# Altium schematic sheet 03_SingleEndstufe.SchDoc(03_SingleEndstufe)
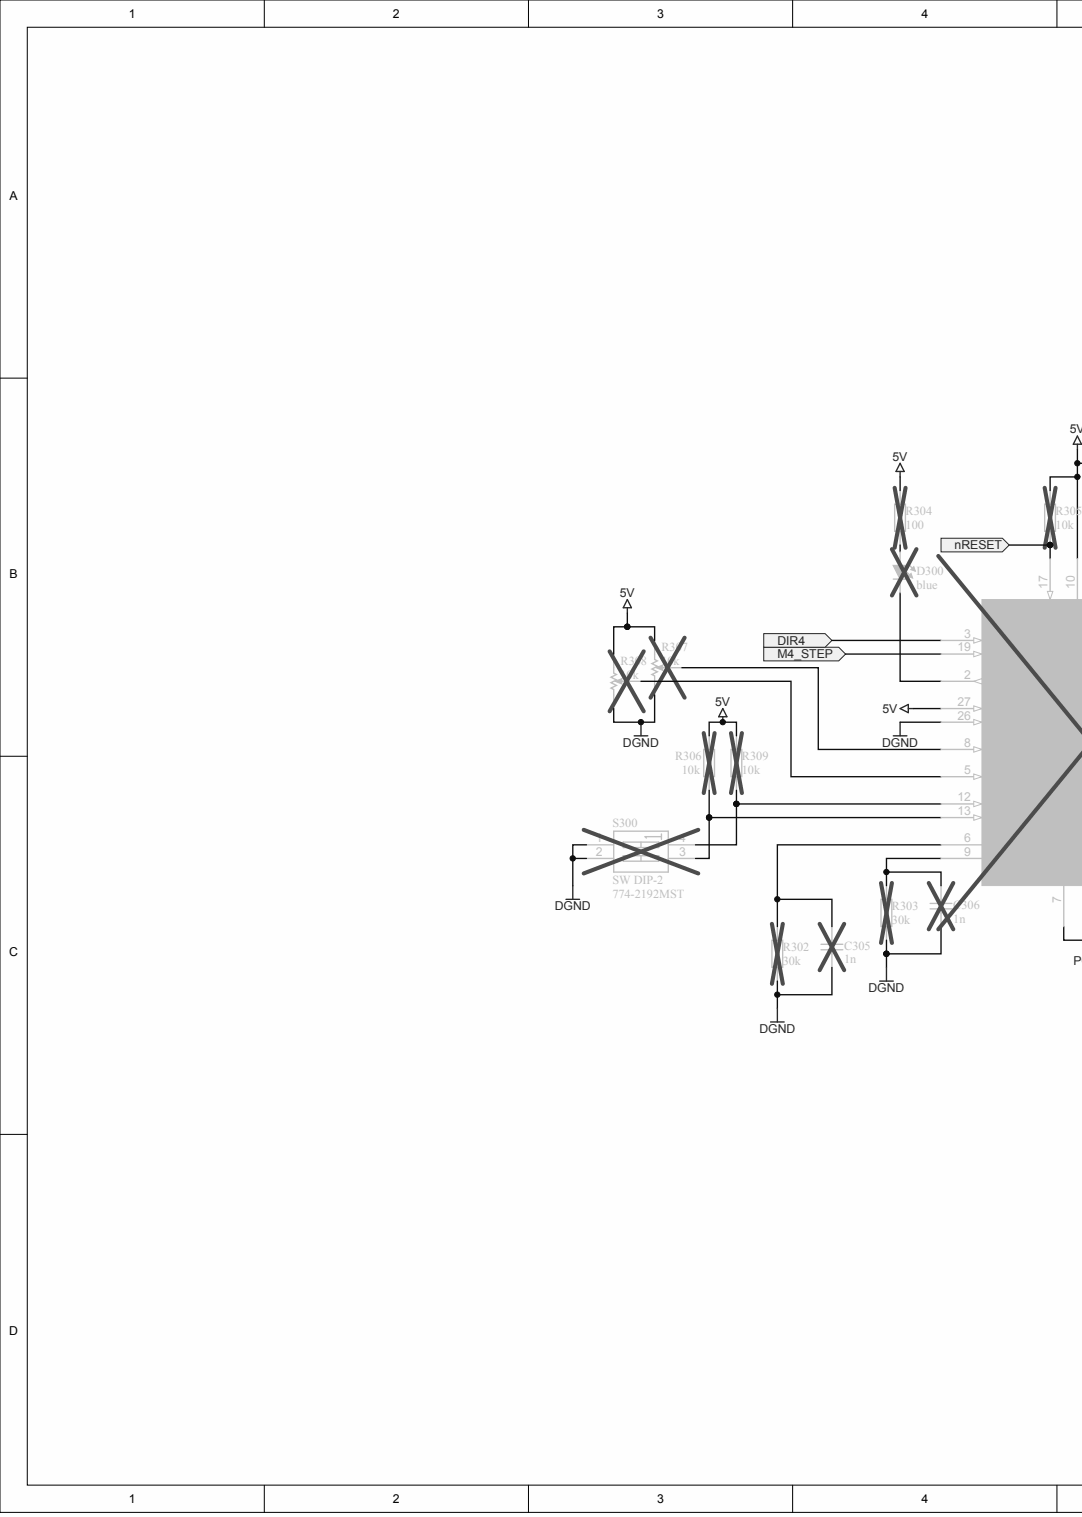
[diagram p0: 03_SingleEndstufe.SchDoc, left]
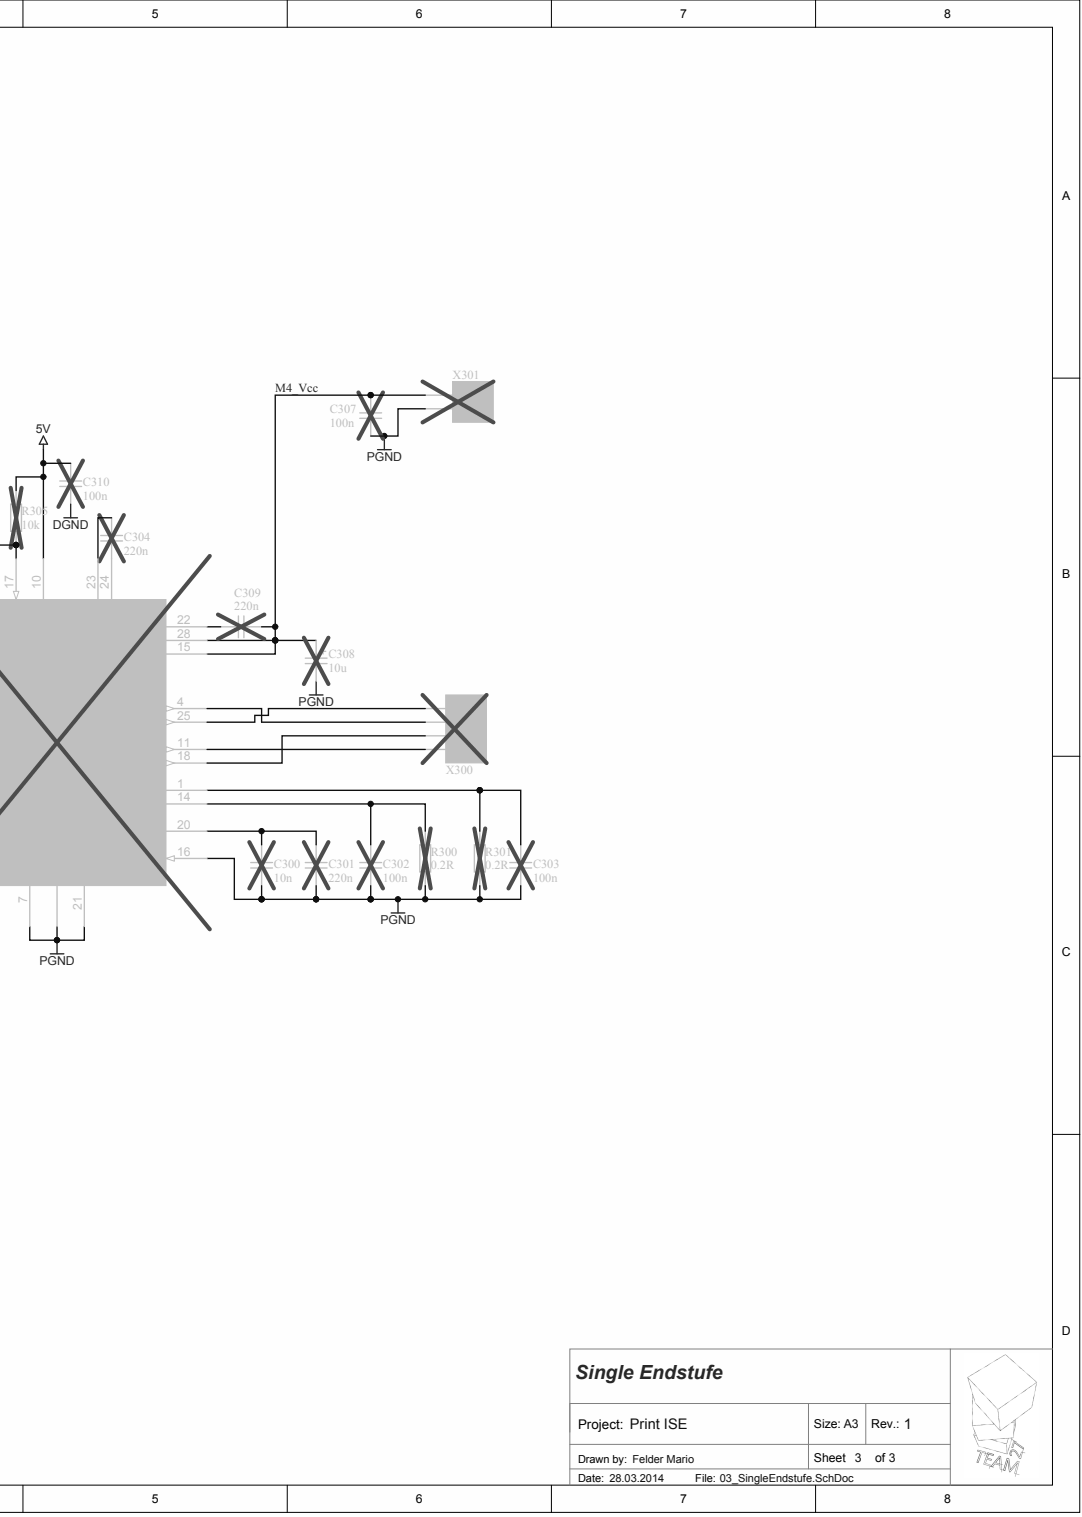
[diagram p1: 03_SingleEndstufe.SchDoc, right]

## Parts
C300  10n
C301  220n
C302  100n
C303  100n
C304  220n
C305  1n
C306  1n
C307  100n
C308  10u
C309  220n
C310  100n
D300  blue
R300  0.2R
R301  0.2R
R302  30k
R303  30k
R304  100
R305  10k
R306  10k
R307  10k
R308  10k
R309  10k
S300  SW DIP-2  Supplier Part Number 1: 774-2192MST
U300  A3977SLP
X300  Motor_Connector  (value not drawn)
X301  Header 2  (value not drawn)

## Ports
DIR4, M4_STEP, NRESET

## Nets
5V: C310.1 R304.2 R305.2 R306.2 R307.1 R308.1 R309.2 U300.10(LVcc) U300.27(SLEEP)
DGND: C305.2 C306.2 C310.2 R302.2 R303.2 R307.3 R308.3 S300.1 S300.2 U300.26(ENABLE)
PGND: C300.2 C301.2 C302.2 C303.2 C307.1 C308.2 R300.2 R301.2 U300.0(PGND) U300.7(AGND) U300.16(SR) U300.21(PGND) X301.2
DIR4: U300.3(DIR) ; port DIR4
M4_STEP: U300.19(STEP) ; port M4_STEP
M4_VCC: C307.2 C308.1 C309.2 U300.15(Vcc_Y2) U300.28(Vcc_Y1) X301.1
NetC300_1: C300.1 C301.1 U300.20(VREG)
NetC302_1: C302.1 R300.1 U300.14(SENSE2)
NetC303_1: C303.1 R301.1 U300.1(SENSE1)
NetC304_1: C304.1 U300.23(CP1)
NetC304_2: C304.2 U300.24(CP2)
NetC305_1: C305.1 R302.1 U300.6(RC1)
NetC306_1: C306.1 R303.1 U300.9(RC2)
NetC309_1: C309.1 U300.22(VCP)
NetD300_1: D300.1 R304.1
NetD300_2: D300.2 U300.2(HOME)
NetR305_1: R305.1 U300.17(RESET) ; port NRESET
NetR306_1: R306.1 S300.3 U300.13(MS1)
NetR307_2: R307.2 U300.8(REF)
NetR308_2: R308.2 U300.5(PFD)
NetR309_1: R309.1 S300.4 U300.12(MS2)
NetU300_4: U300.4(OUT1A) X300.2(A_2)
NetU300_11: U300.11(OUT2A) X300.4(B_2)
NetU300_18: U300.18(OUT2B) X300.3(B_1)
NetU300_25: U300.25(OUT1B) X300.1(A_1)

## Library data of the parts
C308 = (no symbol name): Value: 100pF; Published: 8-Jun-2000; LatestRevisionDate: 17-Jul-2002; LatestRevisionNote: Re-released for DXP Platform.; PackageReference: POLAR0.8; PackageDescription: Polarized Capacitor; 2 Leads; Publisher: Altium Limited
C300-C307 C309 C310 D300 R300-R309 S300 U300 X300 X301 = (no symbol name)
  C300-C307 C309 C310: PackageReference: 0805
  D300: PackageReference: 0603; PackageDescription: SMD LED 06063; Supplier 1: Mouser; Supplier Part Number 1: 78-VLMB1300-GS08
  R300-R306 R309: PackageReference: 0805; PackageDescription: SMD
  R307 R308: Published: 17-Dec-2003; DatasheetVersion: Oct-2003; PackageReference: POT4MM-2; PackageDescription: Square Trimming Potentiometer; ComponentLink1Description: Manufacturer Link; ComponentLink1URL: http://bourns.com/2/search.html
  S300: PackageReference: SMD; Supplier 1: Mouser
  U300: Supplier 1: Digi-Key; Supplier Part Number 1: A3977SLP-ND; PackageReference: TSSOP28
  X301: LatestRevisionDate: 17-Jul-2002; LatestRevisionNote: Re-released for DXP Platform.
  R307 R308 X301: Publisher: Altium Limited
